# Altium schematic sheet CN032-1 Industry EtherCAT Servo Controller_DI-DO.SchDoc
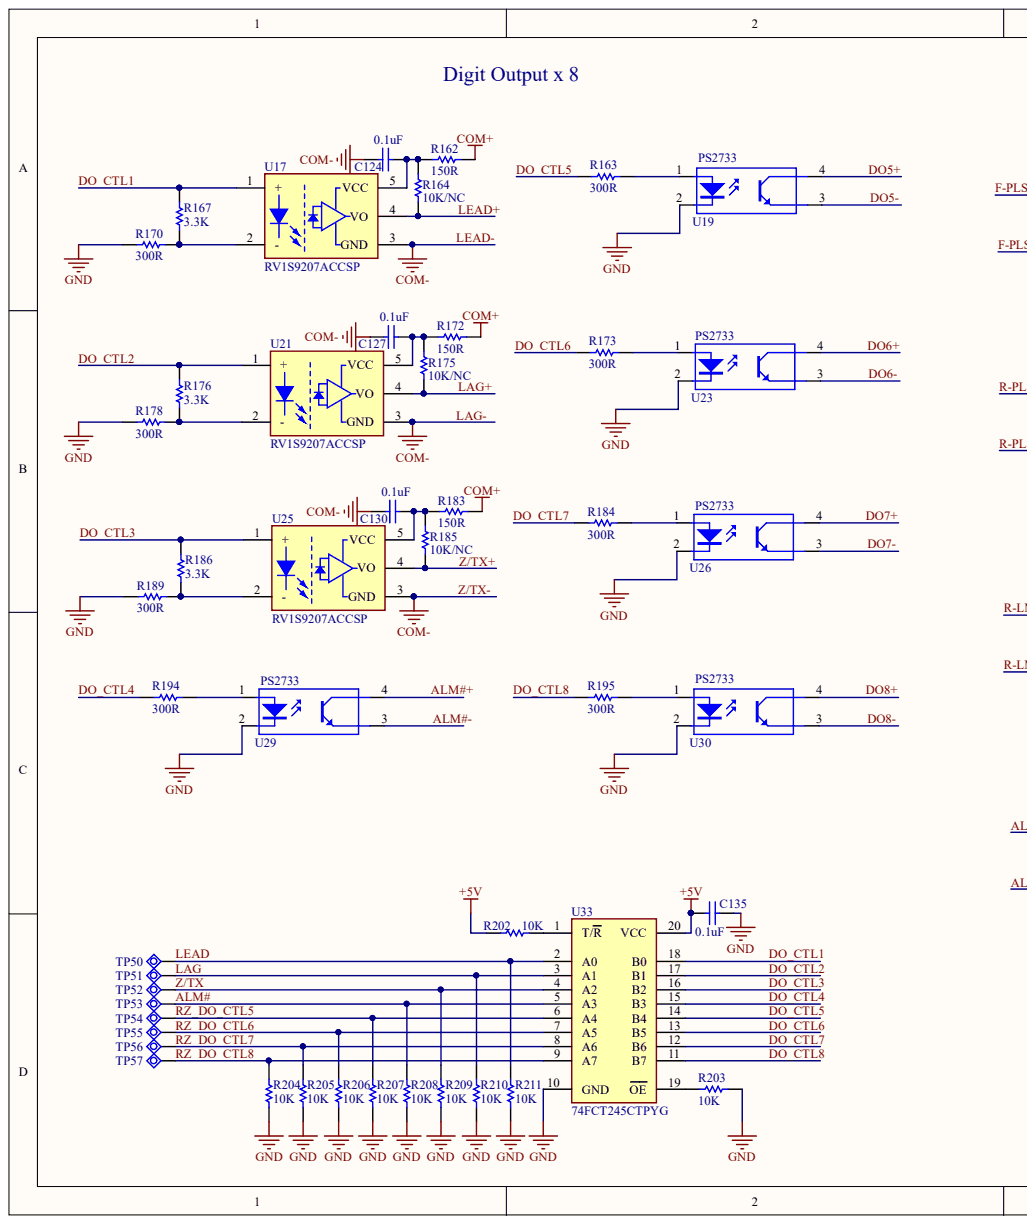
[diagram p0: CN032-1 Industry EtherCAT Servo Controller_DI-DO.SchDoc, left]
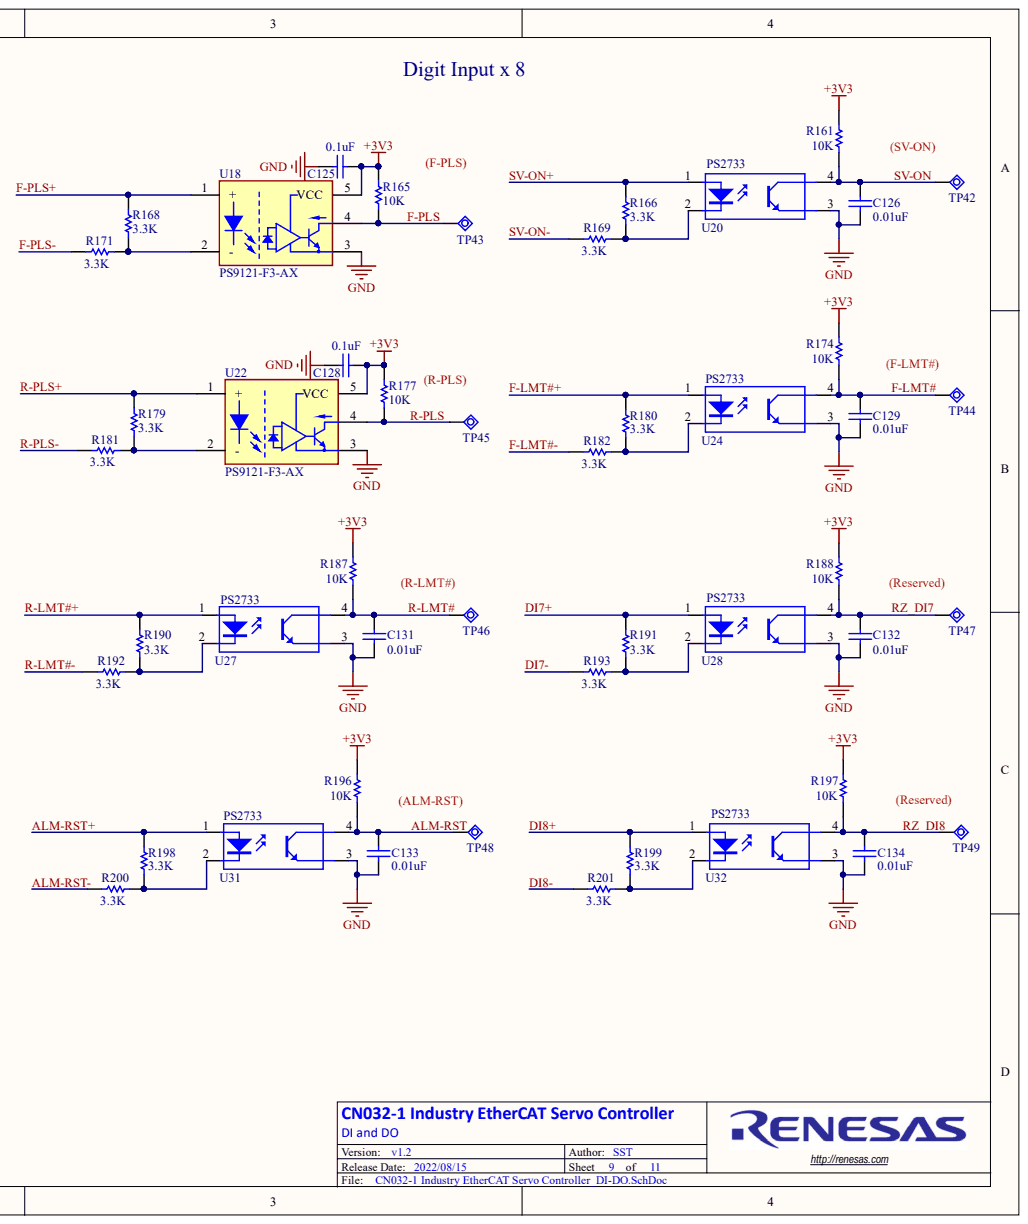
[diagram p1: CN032-1 Industry EtherCAT Servo Controller_DI-DO.SchDoc, right]

## Parts
C124  0.1uF  [CAPC0603-01]
C125  0.1uF  [CAPC0402-01]
C126  0.01uF  [CAPC0402-01]
C127  0.1uF  [CAPC0603-01]
C128  0.1uF  [CAPC0402-01]
C129  0.01uF  [CAPC0402-01]
C130  0.1uF  [CAPC0603-01]
C131  0.01uF  [CAPC0402-01]
C132  0.01uF  [CAPC0402-01]
C133  0.01uF  [CAPC0402-01]
C134  0.01uF  [CAPC0402-01]
C135  0.1uF  [CAPC0402-01]
R161  10K  [RESC0402-01]
R162  150R  [RESC0603-01]
R163  300R  [RESC0603-01]
R164  10K/NC  [RESC0402-01]
R165  10K  [RESC0402-01]
R166  3.3K  [RESC0402-01]
R167  3.3K  [RESC0402-01]
R168  3.3K  [RESC0402-01]
R169  3.3K  [R1210 (3225)]
R170  300R  [RESC0603-01]
R171  3.3K  [R1210 (3225)]
R172  150R  [RESC0603-01]
R173  300R  [RESC0603-01]
R174  10K  [RESC0402-01]
R175  10K/NC  [RESC0402-01]
R176  3.3K  [RESC0402-01]
R177  10K  [RESC0402-01]
R178  300R  [RESC0603-01]
R179  3.3K  [RESC0402-01]
R180  3.3K  [RESC0402-01]
R181  3.3K  [R1210 (3225)]
R182  3.3K  [R1210 (3225)]
R183  150R  [RESC0603-01]
R184  300R  [RESC0603-01]
R185  10K/NC  [RESC0402-01]
R186  3.3K  [RESC0402-01]
R187  10K  [RESC0402-01]
R188  10K  [RESC0402-01]
R189  300R  [RESC0603-01]
R190  3.3K  [RESC0402-01]
R191  3.3K  [RESC0402-01]
R192  3.3K  [R1210 (3225)]
R193  3.3K  [R1210 (3225)]
R194  300R  [RESC0603-01]
R195  300R  [RESC0603-01]
R196  10K  [RESC0402-01]
R197  10K  [RESC0402-01]
R198  3.3K  [RESC0402-01]
R199  3.3K  [RESC0402-01]
R200  3.3K  [R1210 (3225)]
R201  3.3K  [R1210 (3225)]
R202  10K  [RESC0402-01]
R203  10K  [RESC0402-01]
R204  10K  [RESC0402-01]
R205  10K  [RESC0402-01]
R206  10K  [RESC0402-01]
R207  10K  [RESC0402-01]
R208  10K  [RESC0402-01]
R209  10K  [RESC0402-01]
R210  10K  [RESC0402-01]
R211  10K  [RESC0402-01]
TP42  Test Point  [TEST POINT]  (value not drawn)
TP43  Test Point  [TEST POINT]  (value not drawn)
TP44  Test Point  [TEST POINT]  (value not drawn)
TP45  Test Point  [TEST POINT]  (value not drawn)
TP46  Test Point  [TEST POINT]  (value not drawn)
TP47  Test Point  [TEST POINT]  (value not drawn)
TP48  Test Point  [TEST POINT]  (value not drawn)
TP49  Test Point  [TEST POINT]  (value not drawn)
TP50  Test Point  [TEST POINT]  (value not drawn)
TP51  Test Point  [TEST POINT]  (value not drawn)
TP52  Test Point  [TEST POINT]  (value not drawn)
TP53  Test Point  [TEST POINT]  (value not drawn)
TP54  Test Point  [TEST POINT]  (value not drawn)
TP55  Test Point  [TEST POINT]  (value not drawn)
TP56  Test Point  [TEST POINT]  (value not drawn)
TP57  Test Point  [TEST POINT]  (value not drawn)
U17  RV1S9207ACCSP  [RV1S9213A]
U18  PS9121-F3-AX  [PS9121-F3-AX 5SO]
U19  PS2733  [PS2733-1-A]
U20  PS2733  [PS2733-1-A]
U21  RV1S9207ACCSP  [RV1S9213A]
U22  PS9121-F3-AX  [PS9121-F3-AX 5SO]
U23  PS2733  [PS2733-1-A]
U24  PS2733  [PS2733-1-A]
U25  RV1S9207ACCSP  [RV1S9213A]
U26  PS2733  [PS2733-1-A]
U27  PS2733  [PS2733-1-A]
U28  PS2733  [PS2733-1-A]
U29  PS2733  [PS2733-1-A]
U30  PS2733  [PS2733-1-A]
U31  PS2733  [PS2733-1-A]
U32  PS2733  [PS2733-1-A]
U33  74FCT245CTPYG  [74FCT245CTPYG]

## Nets
+3V3: C125.2 C128.2 R161.1 R165.1 R174.1 R177.1 R187.1 R188.1 R196.1 R197.1 U18.5(VCC) U22.5(VCC)
+5V: C135.2 R202.2 U33.20(VCC)
COM+: R162.2 R172.2 R183.2
GND: C125.1 C126.1 C128.1 C129.1 C131.1 C132.1 C133.1 C134.1 C135.1 R170.1 R178.1 R189.1 R203.1 R204.2 R205.2 R206.2 R207.2 R208.2 R209.2 R210.2 R211.2 U18.3 U19.2 U20.3 U22.3 U23.2 U24.3 U26.2 U27.3 U28.3 U29.2 U30.2 U31.3 U32.3 U33.10(GND)
ALM#: R208.1 TP53.1 U33.5(A3)
ALM#+: U29.4
ALM#-: U29.3
ALM-RST: C133.2 R196.2 TP48.1 U31.4
ALM-RST+: R198.2 U31.1
ALM-RST-: R200.1
DI7+: R191.2 U28.1
DI7-: R193.1
DI8+: R199.2 U32.1
DI8-: R201.1
DO5+: U19.4
DO5-: U19.3
DO6+: U23.4
DO6-: U23.3
DO7+: U26.4
DO7-: U26.3
DO8+: U30.4
DO8-: U30.3
DO_CTL1: R167.2 U17.1(+) U33.18(B0)
DO_CTL2: R176.2 U21.1(+) U33.17(B1)
DO_CTL3: R186.2 U25.1(+) U33.16(B2)
DO_CTL4: R194.1 U33.15(B3)
DO_CTL5: R163.1 U33.14(B4)
DO_CTL6: R173.1 U33.13(B5)
DO_CTL7: R184.1 U33.12(B6)
DO_CTL8: R195.1 U33.11(B7)
F-LMT#: C129.2 R174.2 TP44.1 U24.4
F-LMT#+: R180.2 U24.1
F-LMT#-: R182.1
F-PLS: R165.2 TP43.1 U18.4
F-PLS+: R168.2 U18.1(+)
F-PLS-: R171.1
LAG: R210.1 TP51.1 U33.3(A1)
LAG+: R175.2 U21.4(VO)
LAG-: C124.1 C127.1 C130.1 U17.3(GND) U21.3(GND) U25.3(GND)
LEAD: R211.1 TP50.1 U33.2(A0)
LEAD+: R164.2 U17.4(VO)
R-LMT#: C131.2 R187.2 TP46.1 U27.4
R-LMT#+: R190.2 U27.1
R-LMT#-: R192.1
R-PLS: R177.2 TP45.1 U22.4
R-PLS+: R179.2 U22.1(+)
R-PLS-: R181.1
RZ_DI7: C132.2 R188.2 TP47.1 U28.4
RZ_DI8: C134.2 R197.2 TP49.1 U32.4
RZ_DO_CTL5: R207.1 TP54.1 U33.6(A4)
RZ_DO_CTL6: R206.1 TP55.1 U33.7(A5)
RZ_DO_CTL7: R205.1 TP56.1 U33.8(A6)
RZ_DO_CTL8: R204.1 TP57.1 U33.9(A7)
SV-ON: C126.2 R161.2 TP42.1 U20.4
SV-ON+: R166.2 U20.1
SV-ON-: R169.1
Z/TX: R209.1 TP52.1 U33.4(A2)
Z/TX+: R185.2 U25.4(VO)
NetC124_2: C124.2 R162.1 R164.1 U17.5(VCC)
NetC127_2: C127.2 R172.1 R175.1 U21.5(VCC)
NetC130_2: C130.2 R183.1 R185.1 U25.5(VCC)
NetR163_2: R163.2 U19.1
NetR166_1: R166.1 R169.2 U20.2
NetR167_1: R167.1 R170.2 U17.2(-)
NetR168_1: R168.1 R171.2 U18.2(-)
NetR173_2: R173.2 U23.1
NetR176_1: R176.1 R178.2 U21.2(-)
NetR179_1: R179.1 R181.2 U22.2(-)
NetR180_1: R180.1 R182.2 U24.2
NetR184_2: R184.2 U26.1
NetR186_1: R186.1 R189.2 U25.2(-)
NetR190_1: R190.1 R192.2 U27.2
NetR191_1: R191.1 R193.2 U28.2
NetR194_2: R194.2 U29.1
NetR195_2: R195.2 U30.1
NetR198_1: R198.1 R200.2 U31.2
NetR199_1: R199.1 R201.2 U32.2
NetR202_1: R202.1 U33.1(T/R)
NetR203_2: R203.2 U33.19(OE)

## Library data of the parts
C124 C127 C130 = CAP-0603-0.1uF: Ceramic Chip Capacitor 0603 0.1uF 100V; Manufacturer: YAGEO; Mfg Part Number: CC0603KRX7R0BB104; Dialectric: X7R; Tolerance: 10%; Capacitance: 0.1uF; Component Kind: Standard; Package: 0603; Voltage: 100V; Library Name: Synergy.SchLib
C125 C128 C135 = CAP-0402-0.1uF-YAGEO: Ceramic Chip Capacitor 0402 0.1uF 16V; Manufacturer: YAGEO; Mfg Part Number: CC0402KPX7R7BB104; Dialectric: X7R; Tolerance: 10%; Capacitance: 0.1uF; Component Kind: Standard; Package: 0402; Voltage: 16V; Library Name: Synergy.SchLib
C126 C129 C131-C134 = CAP-0402-0.01uF: Ceramic Chip Capacitor 0402 0.01uF 16V; Manufacturer: YAGEO; Mfg Part Number: CC0402KRX7R7BB103; Dialectric: X7R; Tolerance: 10%; Capacitance: 0.01uF; Component Kind: Standard; Package: 0402; Voltage: 16V; Library Name: Synergy.SchLib
R161 R164 R165 R174 R175 R177 R185 R187 R188 R196 R197 R202-R211 = RES-0402-10K: Chip Resistor Thick Film 0402 10K 1% 1/16W; Manufacturer: YAGEO; Mfg Part Number: RC0402FR-0710KL; Component Kind: Standard; Package: 0402; Power: 1/16W; Tolerance: 1%
  R161 R165 R174 R177 R187 R188 R196 R197 R202-R211: Resistance: 10K
  R164 R175 R185: Resistance: 10K/NC
  R161 R174 R187 R188 R196 R197 R202-R211: Library Name: Synergy.SchLib
  R164 R165 R175 R177 R185: Library Name: CN268 IEEE-1588.SchLib
R162 R172 R183 = RES-0603-150R: Chip Resistor Thick Film 0603 150R 1% 1/10W; Manufacturer: YAGEO; Mfg Part Number: RC0603FR-07150RL; Component Kind: Standard; Package: 0603; Power: 1/10W; Resistance: 150R; Tolerance: 1%; Library Name: Synergy.SchLib
R163 R170 R173 R178 R184 R189 R194 R195 = RES-0603-300R: Chip Resistor Thick Film 0603 300R 1% 1/10W; Manufacturer: YAGEO; Mfg Part Number: RC0603FR-07300RL; Component Kind: Standard; Package: 0603; Power: 1/10W; Resistance: 300R; Tolerance: 1%; Library Name: Synergy.SchLib
R166-R168 R176 R179 R180 R186 R190 R191 R198 R199 = RES-0402-3.3K: Chip Resistor Thick Film 0402 3.3K 1% 1/16W; Manufacturer: YAGEO; Mfg Part Number: RC0402FR-073K3L; Component Kind: Standard; Package: 0402; Power: 1/16W; Rating: 1%; Value: 3.3K; Library Name: Synergy.SchLib
R169 R171 R181 R182 R192 R193 R200 R201 = RES-1210-3.3K: Chip Resistor Thick Film 1210 3.3K 5% 1/2W; Manufacturer: YAGEO; Mfg Part Number: RC1210JR-073K3L; Component Kind: Standard; Package: 1210; Power: 1/2W; Resistance: 3.3K; Tolerance: 5%; Library Name: Synergy.SchLib
TP42-TP57 = CON-Test Point: Component Kind: Standard; Description: Test Point; Library Name: Synergy.SchLib
U17 U21 U25 = PC-RV1S9207ACCSP: OPTO COUPLER IN 10V~30V 5-LSSO; Manufacturer: Renesas; Mfg Part Number: RV1S9207ACCSP-10YV#SC0; Component Kind: Standard; Package: 5-LSSO; Value: RV1S9207ACCSP; Library Name: Synergy.IntLib
U18 U22 = PC-PS9121-F3-AX: OPTO COUPLER IN 2.7V~3.6V 5-SO; Manufacturer: Renesas; Component Kind: Standard; Mfg Part Number: PS9121-F3-AX; Package: 5-SO; Value: PS9121-F3-AX; Library Name: Synergy.IntLib
U19 U20 U23 U24 U26-U32 = PC-PS2733: OPTOISOLATOR 2.5KV DARL 4SMD; Manufacturer: Renesas; Component Kind: Standard; Mfg Part Number: PS2733-1-A; Package: 4SMD; Library Name: Synergy.SchLib
U33 = ICN-74FCT245CTPYG: IC TXRX NON-INVERT 5.25V 20SSOP; Manufacturer: Renesas; Component Kind: Standard; Mfg Part Number: 74FCT245CTPYG; Package: 20-SSOP; Library Name: Synergy.SchLib
